# Altium schematic sheet CAN_PHY.SchDoc(CAN_PHY)
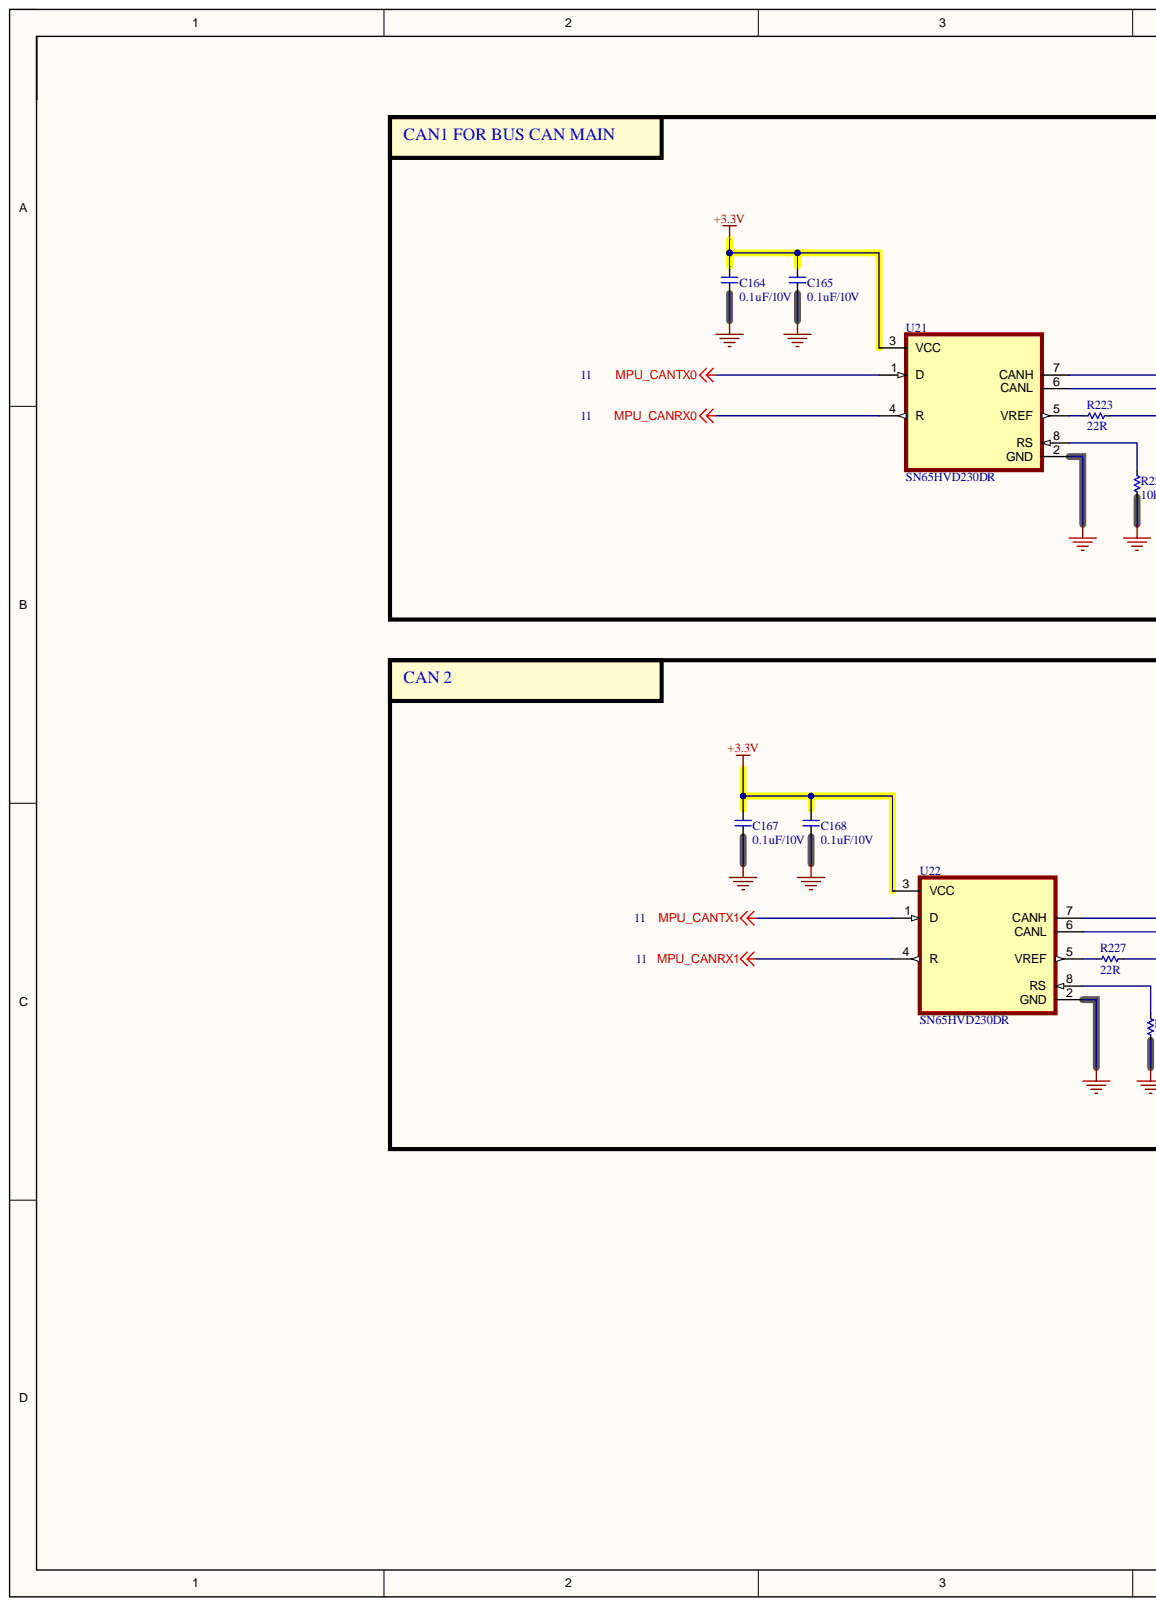
[diagram p0: CAN_PHY.SchDoc, left]
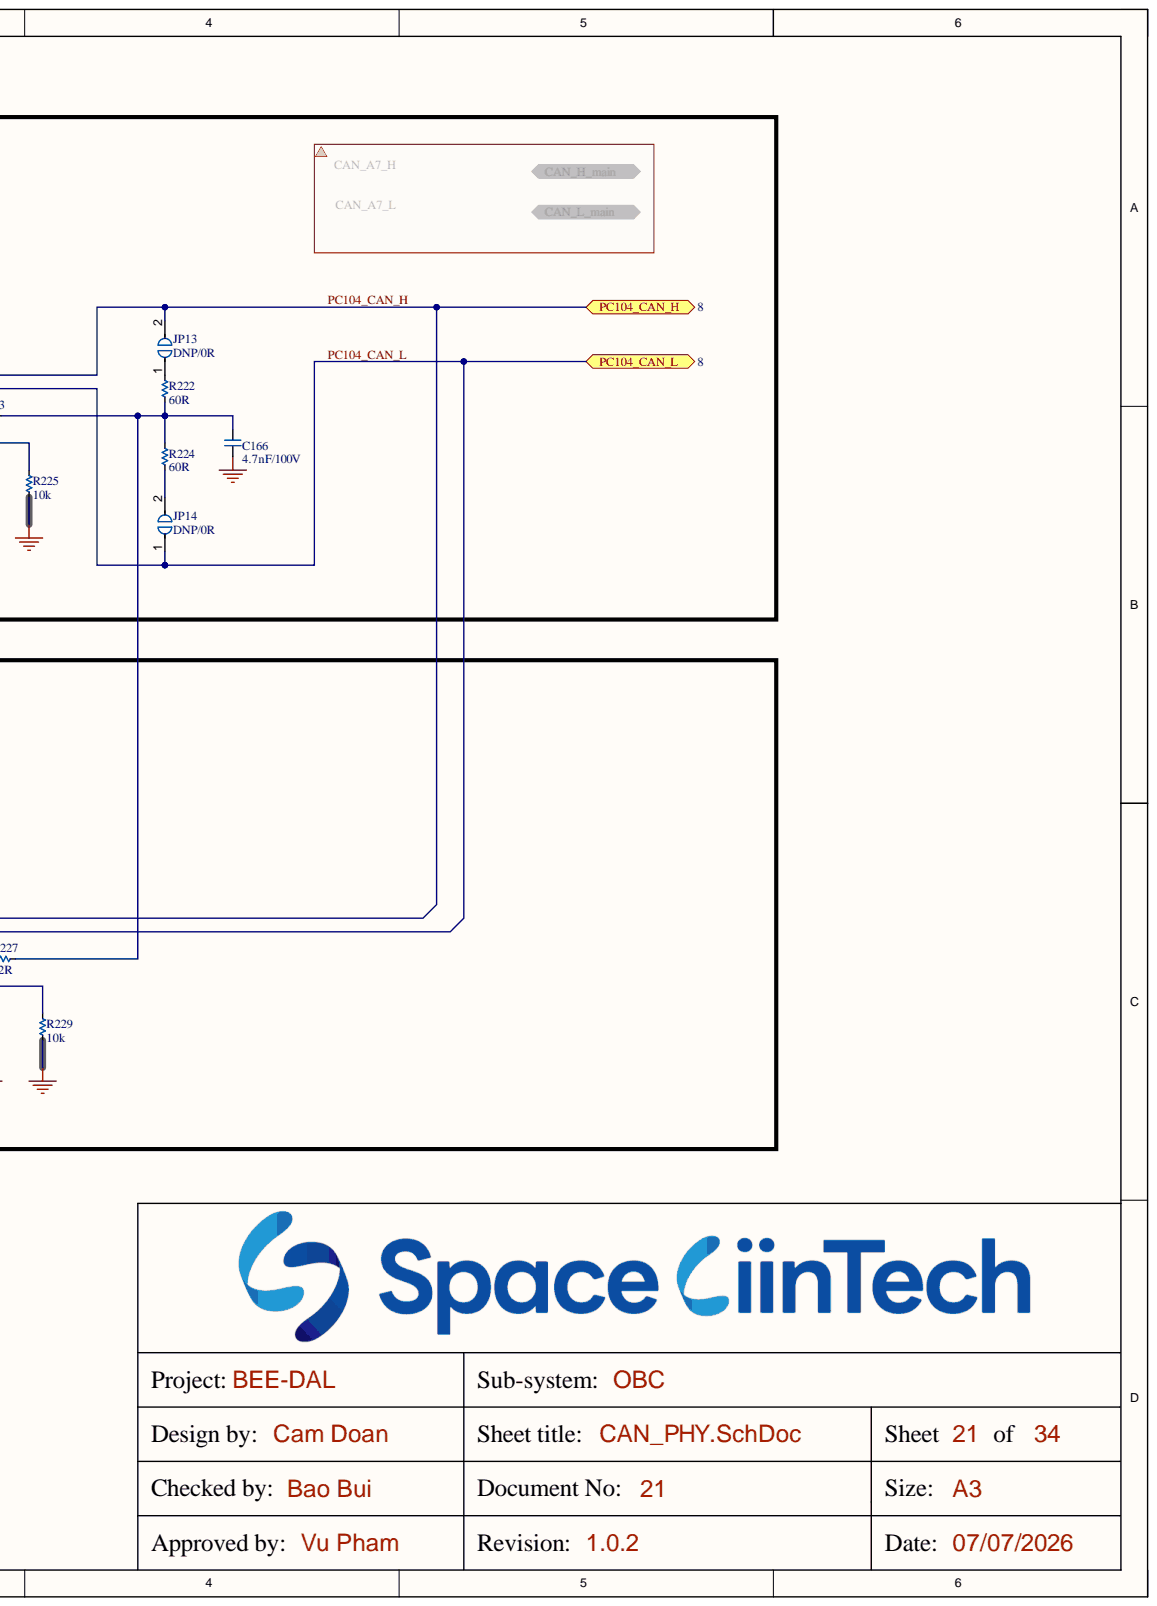
[diagram p1: CAN_PHY.SchDoc, right]

## Parts
C164  0.1uF/10V  [CAP_C_1005X50_L]
C165  0.1uF/10V  [CAP_C_1005X50_L]
C166  4.7nF/100V  [CAP_C_1005X50_L]
C167  0.1uF/10V  [CAP_C_1005X50_L]
C168  0.1uF/10V  [CAP_C_1005X50_L]
JP13  DNP/0R  [RES_C_1608X60]
JP14  DNP/0R  [RES_C_1608X60]
R222  60R  [RES_C_1005x30_L]
R223  22R  [RES_C_1005x30_L]
R224  60R  [RES_C_1005x30_L]
R225  10k  [RES_C_1005x30_L]
R227  22R  [RES_C_1005x30_L]
R229  10k  [RES_C_1005x30_L]
U21  SN65HVD230DR  [SOIC127P602X173-8N]
U22  SN65HVD230DR  [SOIC127P602X173-8N]

## Ports
PC104_CAN_H, PC104_CAN_L

## Nets
+3.3V: C164.2 C165.2 C167.2 C168.2 U21.3(VCC) U22.3(VCC)
GND: C164.1 C165.1 C166.1 C167.1 C168.1 R225.2 R229.2 U21.2(GND) U22.2(GND)
MPU_CANRX0: U21.4(R)
MPU_CANRX1: U22.4(R)
MPU_CANTX0: U21.1(D)
MPU_CANTX1: U22.1(D)
PC104_CAN_H: JP13.2 U21.7(CANH) U22.7(CANH) ; port PC104_CAN_H
PC104_CAN_L: JP14.1 U21.6(CANL) U22.6(CANL) ; port PC104_CAN_L
NetC166_2: C166.2 R222.2 R223.1 R224.1 R227.1
NetJP13_1: JP13.1 R222.1
NetJP14_2: JP14.2 R224.2
NetR223_2: R223.2 U21.5(VREF)
NetR225_1: R225.1 U21.8(RS)
NetR227_2: R227.2 U22.5(VREF)
NetR229_1: R229.1 U22.8(RS)

## Library data of the parts
C164-C168 = _CAP_C_2: CAP; Component Kind: Standard; Library Name: LABLIB.SchLib
JP13 JP14 = JUMPER_1_1: Component Kind: Standard; Library Name: LABLIB.SchLib
R222-R225 R227 R229 = _RES_B_2: RES; Component Kind: Standard
  R222 R224: Library Name: IFBschelib.SchLib
  R223 R225 R227 R229: Library Name: LABLIB.SchLib
U21 U22 = SN65HVD230DR: 3.3 V CAN Transceiver with Standby Mode; MF: Texas Instruments; Check_prices: https://www.snapeda.com/parts/SN65HVD230DR/Texas+Instruments/view-part/?ref=eda; Component Kind: Standard; MP: SN65HVD230DR; Package: SOIC-8 Texas Instruments; SnapEDA_Link: https://www.snapeda.com/parts/SN65HVD230DR/Texas+Instruments/view-part/?ref=snap; Library Name: IFBschelib.SchLib
